# Altium schematic sheet 1166V30-2.SchDoc
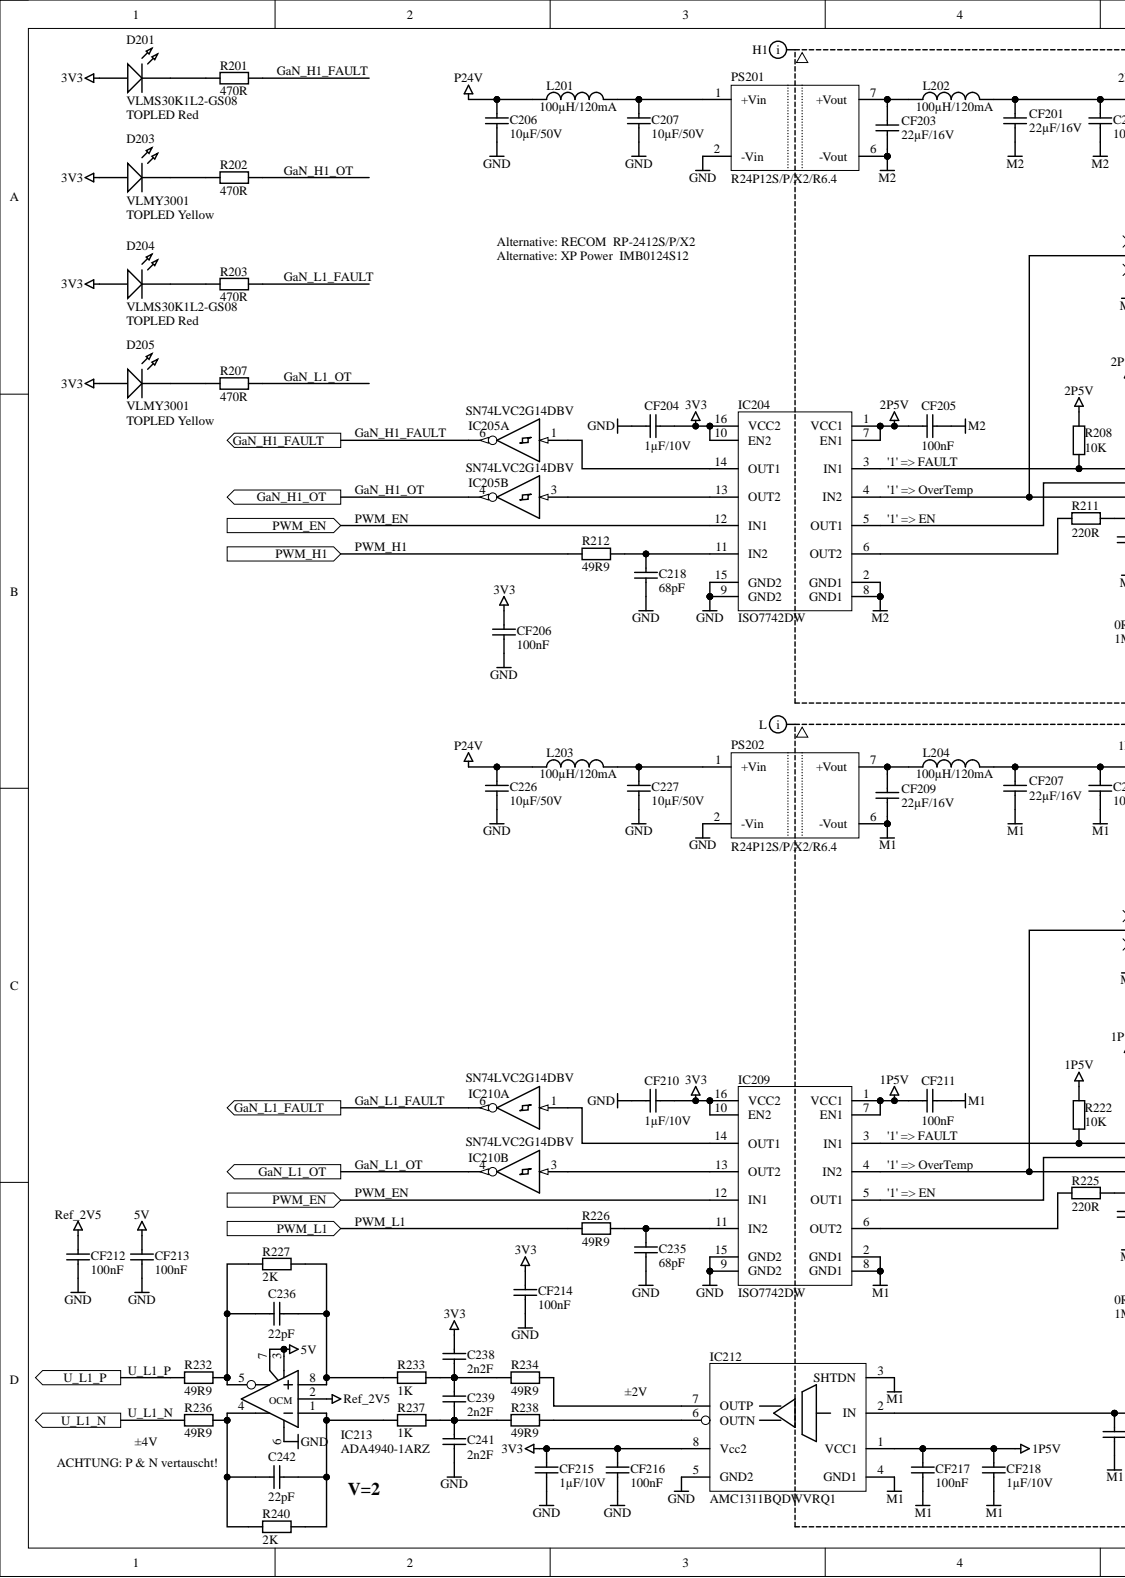
[diagram p0: 1166V30-2.SchDoc, left]
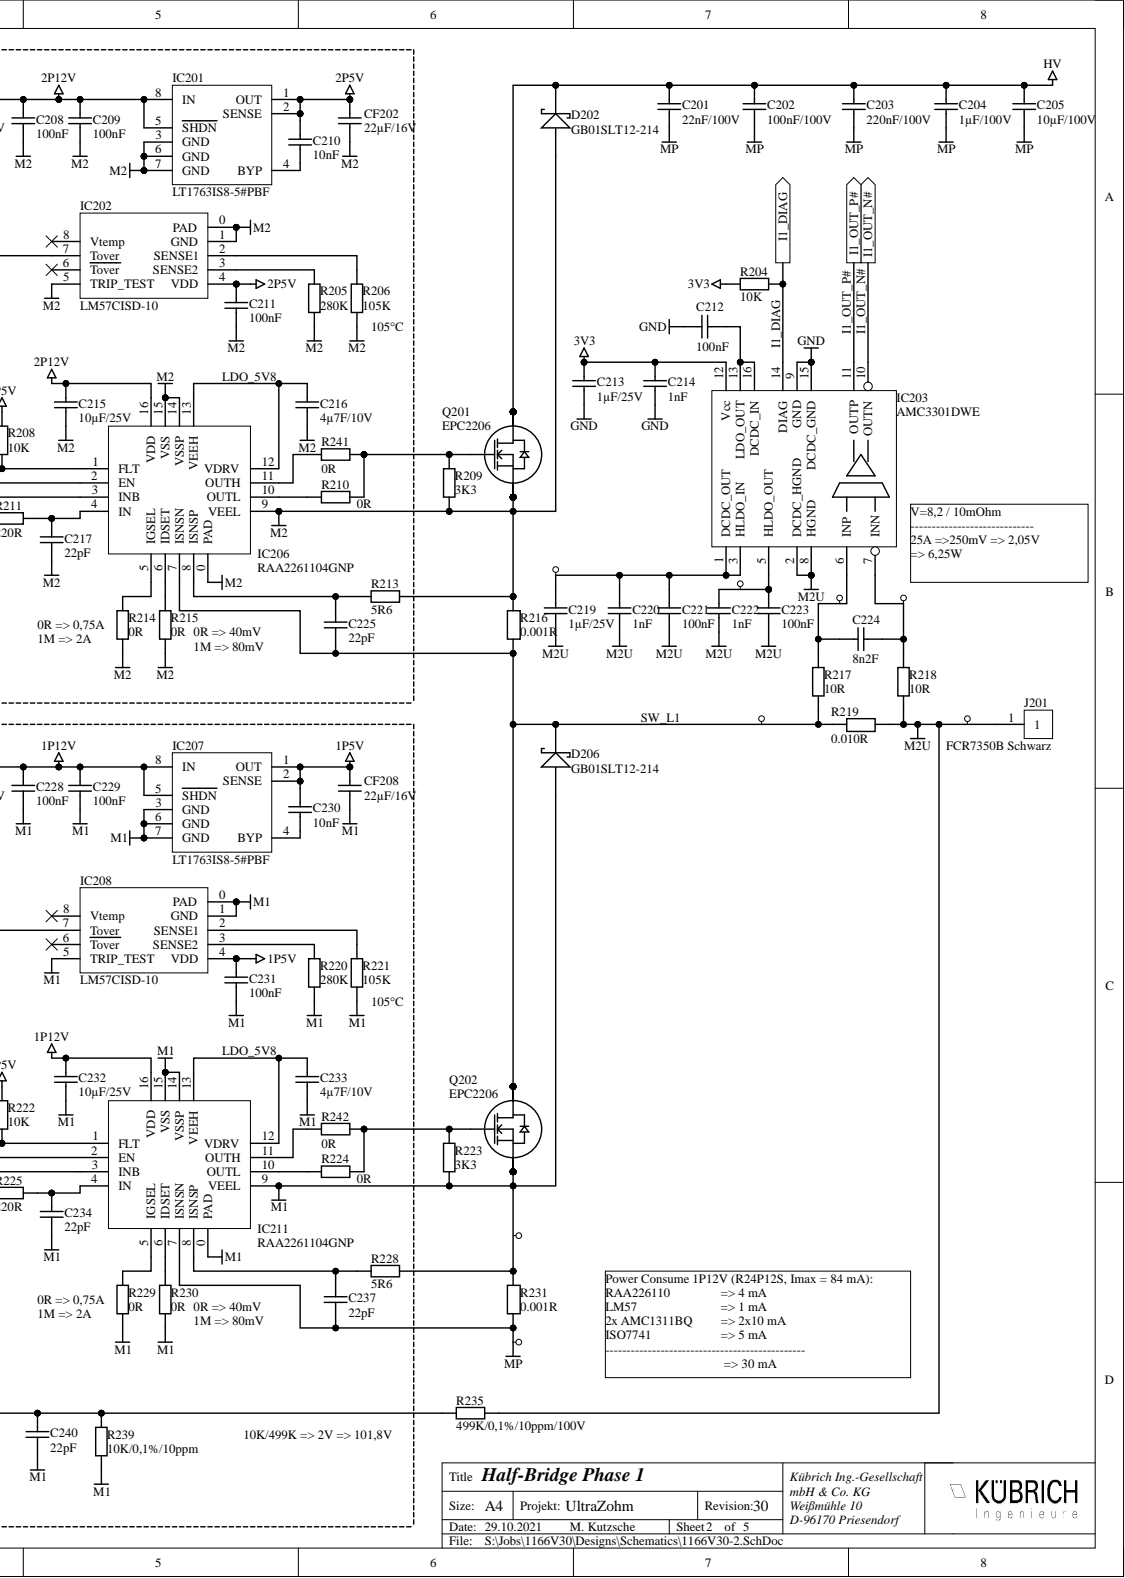
[diagram p1: 1166V30-2.SchDoc, right]

## Parts
C201  22nF/100V  [0805-Cap]
C202  100nF/100V  [0805-Cap]
C203  220nF/100V  [0805-Cap]
C204  1µF/100V  [0805-Cap]
C205  10µF/100V  [1210-Cap]
C206  10µF/50V  [1206-Cap]
C207  10µF/50V  [1206-Cap]
C208  100nF  [0603-Cap]
C209  100nF  [0603-Cap]
C210  10nF  [0603-Cap]
C211  100nF  [0603-Cap]
C212  100nF  [0603-Cap]
C213  1µF/25V  [1206-Cap]
C214  1nF  [1206-Cap]
C215  10µF/25V  [0805-Cap]
C216  4µ7F/10V  [0805-Cap]
C217  22pF  [0603-Cap]
C218  68pF  [0603-Cap]
C219  1µF/25V  [0603-Cap]
C220  1nF  [0603-Cap]
C221  100nF  [0603-Cap]
C222  1nF  [1206-Cap]
C223  100nF  [1206-Cap]
C224  8n2F  [0603-Cap]
C225  22pF  [0603-Cap]
C226  10µF/50V  [1206-Cap]
C227  10µF/50V  [1206-Cap]
C228  100nF  [0603-Cap]
C229  100nF  [0603-Cap]
C230  10nF  [0603-Cap]
C231  100nF  [0603-Cap]
C232  10µF/25V  [0805-Cap]
C233  4µ7F/10V  [0805-Cap]
C234  22pF  [0603-Cap]
C235  68pF  [0603-Cap]
C236  22pF  [0603-Cap]
C237  22pF  [0603-Cap]
C238  2n2F  [0603-Cap]
C239  2n2F  [0603-Cap]
C240  22pF  [0603-Cap]
C241  2n2F  [0603-Cap]
C242  22pF  [0603-Cap]
CF201  22µF/16V  [0805-Cap]
CF202  22µF/16V  [0805-Cap]
CF203  22µF/16V  [0805-Cap]
CF204  1µF/10V  [0603-Cap]
CF205  100nF  [0603-Cap]
CF206  100nF  [0603-Cap]
CF207  22µF/16V  [0805-Cap]
CF208  22µF/16V  [0805-Cap]
CF209  22µF/16V  [0805-Cap]
CF210  1µF/10V  [0603-Cap]
CF211  100nF  [0603-Cap]
CF212  100nF  [0603-Cap]
CF213  100nF  [0603-Cap]
CF214  100nF  [0603-Cap]
CF215  1µF/10V  [0603-Cap]
CF216  100nF  [0603-Cap]
CF217  100nF  [0603-Cap]
CF218  1µF/10V  [0603-Cap]
D201  VLMS30K1L2-GS08  [PLCC-2_rot]
D202  GB01SLT12-214  [DO-214 (SMB)]
D203  VLMY3001  Class II: TOPLED Yellow  [PLCC-2_gelb]
D204  VLMS30K1L2-GS08  Class II: TOPLED Red  [PLCC-2_rot]
D205  VLMY3001  Class II: TOPLED Yellow  [PLCC-2_gelb]
D206  GB01SLT12-214  [DO-214 (SMB)]
IC201  LT1763IS8-5#PBF  [SOIC-8-N]
IC202  LM57CISD-10  [WSON-8 (2.5x2.5mm)]
IC203  AMC3301DWE  [SOIC-16-W - 8.1mm]
IC204  ISO7742DW  [SOIC-16-W - 8.1mm]
IC205  SN74LVC2G14DBV  [SOT-23-6]  (parts A,B)
IC206  RAA2261104GNP  [QFN-16 (4x4mm)]
IC207  LT1763IS8-5#PBF  [SOIC-8-N]
IC208  LM57CISD-10  [WSON-8 (2.5x2.5mm)]
IC209  ISO7742DW  [SOIC-16-W - 8.1mm]
IC210  SN74LVC2G14DBV  [SOT-23-6]  (parts A,B)
IC211  RAA2261104GNP  [QFN-16 (4x4mm)]
IC212  AMC1311BQDWVRQ1  [SOIC-8-W]
IC213  ADA4940-1ARZ  [SOIC-8-N]
J201  FCR7350B Schwarz  [SLB4-I/90 - 4mm - Schwarz - CLIFF]
L201  100µH/120mA  [1007-IND (2518)]
L202  100µH/120mA  [1007-IND (2518)]
L203  100µH/120mA  [1007-IND (2518)]
L204  100µH/120mA  [1007-IND (2518)]
PS201  R24P12S/P/X2/R6.4  [SIP-7 Single - X2]
PS202  R24P12S/P/X2/R6.4  [SIP-7 Single - X2]
Q201  EPC2206  [D0232]
Q202  EPC2206  [D0232]
R201  470R  [0603-Res]
R202  470R  [0603-Res]
R203  470R  [0603-Res]
R204  10K  [0603-Res]
R205  280K  [0603-Res]
R206  105K  [0603-Res]
R207  470R  [0603-Res]
R208  10K  [0603-Res]
R209  3K3  [0603-Res]
R210  0R  [0603-Res]
R211  220R  [0603-Res]
R212  49R9  [0603-Res]
R213  5R6  [0603-Res]
R214  0R  [0603-Res]
R215  0R  [0603-Res]
R216  0.001R  [2512]
R217  10R  [0603-Res]
R218  10R  [0603-Res]
R219  0.010R  [GMR320]
R220  280K  [0603-Res]
R221  105K  [0603-Res]
R222  10K  [0603-Res]
R223  3K3  [0603-Res]
R224  0R  [0603-Res]
R225  220R  [0603-Res]
R226  49R9  [0603-Res]
R227  2K  [0603-Res]
R228  5R6  [0603-Res]
R229  0R  [0603-Res]
R230  0R  [0603-Res]
R231  0.001R  [2512]
R232  49R9  [0603-Res]
R233  1K  [0603-Res]
R234  49R9  [0603-Res]
R235  499K/0,1%/10ppm/100V  [0805-Res]
R236  49R9  [0603-Res]
R237  1K  [0603-Res]
R238  49R9  [0603-Res]
R239  10K/0,1%/10ppm  [0603-Res]
R240  2K  [0603-Res]
R241  0R  [0603-Res]
R242  0R  [0603-Res]

## Ports
GaN_H1_FAULT, GaN_H1_OT, GaN_L1_FAULT, GaN_L1_OT, I1_DIAG, I1_OUT_N#, I1_OUT_P#, PWM_EN, PWM_H1, PWM_L1, U_L1_N, U_L1_P

## Nets
1P5V: C230.1 C231.1 CF208.1 CF211.2 CF217.1 CF218.1 IC207.1(OUT) IC207.2(SENSE) IC208.4(VDD) IC209.1(VCC1) IC209.7(EN1) IC212.1(VCC1) R222.1
1P12V: C228.1 C229.1 C232.2 CF207.1 IC207.5(SHDN) IC207.8(IN) IC211.16(VDD) L204.2
2P5V: C210.1 C211.1 CF202.1 CF205.2 IC201.1(OUT) IC201.2(SENSE) IC202.4(VDD) IC204.1(VCC1) IC204.7(EN1) R208.1
2P12V: C208.1 C209.1 C215.2 CF201.1 IC201.5(SHDN) IC201.8(IN) IC206.16(VDD) L202.2
3V3: C213.1 C214.1 C238.2 CF204.1 CF206.1 CF210.1 CF214.1 CF215.1 CF216.1 D201.1 D203.1 D204.1 D205.1 IC203.12(Vcc) IC204.10(EN2) IC204.16(VCC2) IC209.10(EN2) IC209.16(VCC2) IC212.8(Vcc2) R204.2
5V: CF213.1 IC213.3 IC213.7
GND: C206.1 C207.1 C212.1 C213.2 C214.2 C218.1 C226.1 C227.1 C235.1 C241.1 CF204.2 CF206.2 CF210.2 CF212.2 CF213.2 CF214.2 CF215.2 CF216.2 IC203.9(GND) IC203.15(DCDC_GND) IC204.9(GND2) IC204.15(GND2) IC205.2 IC209.9(GND2) IC209.15(GND2) IC210.2 IC212.5(GND2) IC213.6 PS201.2(-Vin) PS202.2(-Vin)
HV: C201.1 C202.1 C203.1 C204.1 C205.1 D202.2 Q201.3 Q201.4 Q201.7 Q201.8 Q201.11 Q201.12 Q201.15 Q201.16 Q201.19 Q201.20 Q201.23 Q201.24 Q201.27 Q201.28
M1: C228.2 C229.2 C231.2 C232.1 C233.2 C234.1 C240.1 CF207.2 CF208.2 CF209.2 CF211.1 CF217.2 CF218.2 D206.1 IC207.3(GND) IC207.6(GND) IC207.7(GND) IC208.0(PAD) IC208.1(GND) IC208.5(TRIP_TEST) IC209.2(GND1) IC209.8(GND1) IC211.0(PAD) IC211.9(VEEL) IC211.14(VSSP) IC211.15(VSS) IC212.3(SHTDN) IC212.4(GND1) PS202.6(-Vout) Q202.2 Q202.5 Q202.6 Q202.9 Q202.10 Q202.13 Q202.14 Q202.17 Q202.18 Q202.21 Q202.22 Q202.25 Q202.26 Q202.29 Q202.30 R220.2 R221.2 R223.1 R228.2 R229.2 R230.2 R231.2 R239.2
M2: C208.2 C209.2 C211.2 C215.1 C216.2 C217.1 CF201.2 CF202.2 CF203.2 CF205.1 D202.1 IC201.3(GND) IC201.6(GND) IC201.7(GND) IC202.0(PAD) IC202.1(GND) IC202.5(TRIP_TEST) IC204.2(GND1) IC204.8(GND1) IC206.0(PAD) IC206.9(VEEL) IC206.14(VSSP) IC206.15(VSS) PS201.6(-Vout) Q201.2 Q201.5 Q201.6 Q201.9 Q201.10 Q201.13 Q201.14 Q201.17 Q201.18 Q201.21 Q201.22 Q201.25 Q201.26 Q201.29 Q201.30 R205.2 R206.2 R209.1 R213.2 R214.2 R215.2 R216.2
M2U: C219.2 C220.2 C221.2 C222.2 C223.2 IC203.2(DCDC_HGND) IC203.8(HGND) J201.1 R218.1 R219.1 R235.2
MP: C201.2 C202.2 C203.2 C204.2 C205.2 C237.1 IC211.7(ISNSN) R231.1
P24V: C206.2 C226.2 L201.1 L203.1
Ref_2V5: CF212.1 IC213.2(OCM)
VCC: IC205.5 IC210.5
GaN_H1_FAULT: IC205.6 R201.2 ; port GaN_H1_FAULT
GaN_H1_OT: IC205.4 R202.2 ; port GaN_H1_OT
GaN_L1_FAULT: IC210.6 R203.2 ; port GaN_L1_FAULT
GaN_L1_OT: IC210.4 R207.2 ; port GaN_L1_OT
I1_DIAG: IC203.14(DIAG) R204.1 ; port I1_DIAG
I1_OUT_N#: IC203.10(OUTN) ; port I1_OUT_N#
I1_OUT_P#: IC203.11(OUTP) ; port I1_OUT_P#
PWM_EN: IC204.12(IN1) IC209.12(IN1) ; port PWM_EN
PWM_H1: R212.2 ; port PWM_H1
PWM_L1: R226.2 ; port PWM_L1
SW_L1: C225.1 D206.2 IC206.7(ISNSN) Q202.3 Q202.4 Q202.7 Q202.8 Q202.11 Q202.12 Q202.15 Q202.16 Q202.19 Q202.20 Q202.23 Q202.24 Q202.27 Q202.28 R216.1 R217.1 R219.2
U_L1_N: R236.2 ; port U_L1_N
U_L1_P: R232.2 ; port U_L1_P
NetC207_2: C207.2 L201.2 PS201.1(+Vin)
NetC210_2: C210.2 IC201.4(BYP)
NetC212_2: C212.2 IC203.13(LDO_OUT) IC203.16(DCDC_IN)
NetC216_1: C216.1 IC206.12(VDRV) IC206.13(VEEH)
NetC217_2: C217.2 IC206.4(IN) R211.1
NetC218_2: C218.2 IC204.11(IN2) R212.1
NetC219_1: C219.1 C220.1 C221.1 IC203.1(DCDC_OUT) IC203.3(HLDO_IN)
NetC222_1: C222.1 C223.1 IC203.5(HLDO_OUT)
NetC224_1: C224.1 IC203.7(INN) R218.2
NetC224_2: C224.2 IC203.6(INP) R217.2
NetC225_2: C225.2 IC206.8(ISNSP) R213.1
NetC227_2: C227.2 L203.2 PS202.1(+Vin)
NetC230_2: C230.2 IC207.4(BYP)
NetC233_1: C233.1 IC211.12(VDRV) IC211.13(VEEH)
NetC234_2: C234.2 IC211.4(IN) R225.1
NetC235_2: C235.2 IC209.11(IN2) R226.1
NetC236_1: C236.1 IC213.8 R227.1 R233.2
NetC236_2: C236.2 IC213.5 R227.2 R232.1
NetC237_2: C237.2 IC211.8(ISNSP) R228.1
NetC238_1: C238.1 C239.2 R233.1 R234.2
NetC239_1: C239.1 C241.2 R237.1 R238.2
NetC240_2: C240.2 IC212.2(IN) R235.1 R239.1
NetC242_1: C242.1 IC213.1 R237.2 R240.1
NetC242_2: C242.2 IC213.4 R236.1 R240.2
NetCF203_1: CF203.1 L202.1 PS201.7(+Vout)
NetCF209_1: CF209.1 L204.1 PS202.7(+Vout)
NetD201_2: D201.2 R201.1
NetD203_2: D203.2 R202.1
NetD204_2: D204.2 R203.1
NetD205_2: D205.2 R207.1
NetIC202_2: IC202.2(SENSE1) R206.1
NetIC202_3: IC202.3(SENSE2) R205.1
NetIC202_7: IC202.7(Tover) IC204.4(IN2) IC206.3(INB)
NetIC204_3: IC204.3(IN1) IC206.1(FLT) R208.2
NetIC204_5: IC204.5(OUT1) IC206.2(EN)
NetIC204_6: IC204.6(OUT2) R211.2
NetIC204_13: IC204.13(OUT2) IC205.3
NetIC204_14: IC204.14(OUT1) IC205.1
NetIC206_5: IC206.5(IGSEL) R214.1
NetIC206_6: IC206.6(IDSET) R215.1
NetIC206_10: IC206.10(OUTL) R210.1
NetIC206_11: IC206.11(OUTH) R241.1
NetIC208_2: IC208.2(SENSE1) R221.1
NetIC208_3: IC208.3(SENSE2) R220.1
NetIC208_7: IC208.7(Tover) IC209.4(IN2) IC211.3(INB)
NetIC209_3: IC209.3(IN1) IC211.1(FLT) R222.2
NetIC209_5: IC209.5(OUT1) IC211.2(EN)
NetIC209_6: IC209.6(OUT2) R225.2
NetIC209_13: IC209.13(OUT2) IC210.3
NetIC209_14: IC209.14(OUT1) IC210.1
NetIC211_5: IC211.5(IGSEL) R229.1
NetIC211_6: IC211.6(IDSET) R230.1
NetIC211_10: IC211.10(OUTL) R224.1
NetIC211_11: IC211.11(OUTH) R242.1
NetIC212_6: IC212.6(OUTN) R238.1
NetIC212_7: IC212.7(OUTP) R234.1
NetQ201_1: Q201.1 R209.2 R210.2 R241.2
NetQ202_1: Q202.1 R223.2 R224.2 R242.2

Not connected: IC202 6(Tover) 8(Vtemp); IC208 6(Tover) 8(Vtemp)

## Library data of the parts
C201-C242 CF201-CF218 = Cap_SMD: Capacitor (SMD); Class I: Pasiv; Class II: Capacitor; Component Kind: Standard; Last edited: 20.11.2006 10:25; Library Name: KIng_RLC.IntLib
  C201: value: 22nF/100V
  C202: value: 100nF/100V
  C203: value: 220nF/100V
  C204: value: 1µF/100V
  C205: value: 10µF/100V
  C206 C207 C226 C227: value: 10µF/50V
  C208 C209 C211 C212 C221 C223 C228 C229 C231 CF205 CF206 CF211-CF214 CF216 CF217: value: 100nF
  C210 C230: value: 10nF
  C213 C219: value: 1µF/25V
  C214 C220 C222: value: 1nF
  C215 C232: value: 10µF/25V
  C216 C233: value: 4µ7F/10V
  C217 C225 C234 C236 C237 C240 C242: value: 22pF
  C218 C235: value: 68pF
  C224: value: 8n2F
  C238 C239 C241: value: 2n2F
  CF201-CF203 CF207-CF209: value: 22µF/16V
  CF204 CF210 CF215 CF218: value: 1µF/10V
D201 = VLMS30K1L2-GS08: Top LED Super red, 2mA, 18mcd, -40-100°C; Manufacturer: Vishay; Best.-Nr.: Farnell 132-8349; Class I: Discrete; Class II: TOPLED Red; Component Kind: Standard; Last edited: 23.01.2007 08:58; Library Name: KIng_Dioden.IntLib
D202 D206 = GB01SLT12-214: Silicon Carbide Schottky Diode Vr=1200V If=1A; Manufacturer: GeneSiC Semiconductor; Best.-Nr.: DigiKey: 1242-1169-1-ND; Class I: Discret; Package information: Unknown; Class II: Silicon Carbide Schottky Diode Vr=1200V If=1A; Component Kind: Standard; Datasheet: Ver. 7 Nov 2000; Package reference: DO-214AA (SMB); Library Name: KIng_Dioden.IntLib
D203 D205 = VLMY3001: Top LED Yellow, 2mA, 18mcd, -40-100°C; Manufacturer: Vishay; Best.-Nr.: ???; Class I: Discrete; Component Kind: Standard; Last edited: 23.01.2007 08:58; Library Name: KIng_Dioden.IntLib
D204 = VLMS30K1L2-GS08: Top LED Super red, 2mA, 18mcd, -40-100°C; Manufacturer: Vishay; Best.-Nr.: Farnell 132-8349; Class I: Discrete; Component Kind: Standard; Last edited: 23.01.2007 08:58; Library Name: KIng_Dioden.IntLib
IC201 IC207 = LT1763IS8-5#PBF: LDO 5V/500mA, Vin 20V (-40-125°C); Manufacturer: Linear Technology; Best.-Nr.: Farnell 229-5214; Class I: Discret; Package information: Unknown; Class II: LDO 5V/500mA, Vin 20V (-40-125°C); Component Kind: Standard; Datasheet: ???; Package reference: SO-8; Library Name: KIng_Voltage Regulator.IntLib
IC202 IC208 = LM57CISD-10: Temperature Sensor/Switch -40 - 150°C, Resistor-Programmable, 10°C Hysterese; Manufacturer: Texas Instruments; Best.-Nr.: DigiKey: LM57CISD-10/NOPBCT-ND; Class I: Sensor; Class II: Temperature Sensor/Switch -40 - 150°C, Resistor-Programmable, 10°C Hysterese; Component Kind: Standard; Library Name: King_Sensor.IntLib
IC203 = AMC3301DWE: ±250 mV Input Voltage Range, Reinforced Isolated Amplifier and High Bandwidth of 300 kHz; Manufacturer: Texas Instruments; Best.-Nr.: DigiKey: 296-AMC3301DWE-ND; Class II: ±250 mV, 300KHz, -40-125°C; Package information: Unknown; Class I: Isolated Amplifier; Component Kind: Standard; Datasheet: Unknown; Package reference: SOIC-16-W; Library Name: KIng_Opto-Coupler.IntLib
IC204 IC209 = ISO7742DW: Quad-CH 100Mbps Isolators 2 IN/2 OUT, 5000V Reinforced, (-40-125°C); Manufacturer: Texas Instruments; Best.-Nr.: DigiKey: 296-44609-1-ND; Class I: Optocoupler; Package information: Unknown; Class II: Quad-CH 100Mbps Isolators 2 IN/2 OUT, 5000V Reinforced, (-40-125°C); Component Kind: Standard; Datasheet: Unknown; Package reference: SOIC-16W; Library Name: KIng_Opto-Coupler.IntLib
IC205 IC210 = SN74LVC2G14D_: Dual Inverter with Schmitt Trigger Input; Manufacturer: Texas Instrument; Class I: Logic; value121: SN74LVC2G14DCK; Class II: Dual Inverter with Schmitt Trigger Input; Component Kind: Standard; Datasheet: SCES200J; Last edited: 22.01.2007 11:08; value111: SN74LVC2G14DBV; Library Name: KIng_Logik.IntLib
IC206 IC211 = RAA2261104GNP: Low -Side GaN FET-Driver, 6,5V - 18V; Manufacturer: Renesas; Best.-Nr.: Mouser: 968-2261104GNP#AA0; Class I: Discret; Package information: Unknown; Class II: Low -Side GaN FET-Driver, 6,5V - 18V; Component Kind: Standard; Datasheet: Rev. Mar 2002; Package reference: QFN-16; Library Name: KIng_MOSFET.IntLib
IC212 = AMC1311BQDWVRQ1: 2V Input, Automotive High-Impedance, Reinforced Isolated Amplifier for Voltage Sensing; Manufacturer: Texas Instruments; Best.-Nr.: Mouser: 595-AMC1311BQDWVRQ1; Class II: 2V, 275KHz, -40-125°C; Package information: Unknown; Class I: Isolated Amplifier; Component Kind: Standard; Datasheet: Unknown; Package reference: SOIC-8-W; Library Name: KIng_Opto-Coupler.IntLib
IC213 = ADA4940-1ARZ: Fully Differential ADC Drivers (-40-125°C); Manufacturer: ANALOG DEVICES; Best.-Nr.: Farnell 209-6178; Class I: Analog; Class II: Fully Differential ADC Drivers (-40-125°C); Component Kind: Standard; Datasheet: DSO11449, Ver. Aug 2000; Last edited: 04.01.2007 10:14; Package Reference: SOIC-N-8; Library Name: KIng_Analog-IC.IntLib
J201 = FCR7350B Schwarz: Labor Winkelbuchsen 4mm Schwarz; Manufacturer: CLIFF Electronic; Best.-Nr.: Farnell: 185-4507; Class I: Connectors; Class II: Labor Winkelbuchsen 4mm Schwarz; Component Kind: Standard; Last edited: 07.03.2007 13:28; Library Name: KIng_Connector.IntLib
L201-L204 = CB2518T101K: 100µH, 60/120mA. (1007), -40-105°C; Manufacturer: Taiyo Yuden; Best.-Nr.: DigiKey:   587-2192-1-ND; Class I: Pasive; Class II: 100µH, 60/120mA. (1007), -40-105°C; Component Kind: Standard; Last edited: 08.12.2006 12:28; Library Name: KIng_RLC.IntLib
PS201 PS202 = R24P12S/P/X2/R6.4: DC/DC Converter 24V to 12V, 1W, 84mA (unregulated) 6,4KVDC Rainforced; Manufacturer: Recom; Best.-Nr.: Mouser: 919-R24P12SX2PR6.4; Class I: Analog; Package information: Unknown; Class II: DC/DC Converter 24V to 12V, 1W, 84mA (unregulated) 6,4KVDC Rainforced; Component Kind: Standard; Datasheet: Rev. 28 Apr 2002; Library Name: KIng_DCDC-Converter.IntLib; Package reference: SIP-7
Q201 Q202 = EPC2206: GaN-Fet 80V, 2.2mOhm, 90A; Manufacturer: EPC; Best.-Nr.: DigiKey: 917-1212-1-ND; Class I: Discret; Package information: Unknown; Class II: GaN-Fet 80V, 2.2mOhm, 90A; Component Kind: Standard; Datasheet: Rev. Mar 2002; Package reference: DIE; Library Name: KIng_MOSFET.IntLib
R201-R215 R217 R218 R220-R230 R232-R242 = Res_SMD: Resistor SMD; Class I: Pasiv; Class II: Resistor; Component Kind: Standard; Last edited: 17.11.2006 11:38; Library Name: KIng_RLC.IntLib
  R201-R203 R207: value: 470R
  R204 R208 R222: value: 10K
  R205 R220: value: 280K
  R206 R221: value: 105K
  R209 R223: value: 3K3
  R210 R214 R215 R224 R229 R230 R241 R242: value: 0R
  R211 R225: value: 220R
  R212 R226 R232 R234 R236 R238: value: 49R9
  R213 R228: value: 5R6
  R217 R218: value: 10R
  R227 R240: value: 2K
  R233 R237: value: 1K
  R235: value: 499K/0,1%/10ppm/100V
  R239: value: 10K/0,1%/10ppm
R216 R231 = CSS2H-2512R-1L00F: Shunt 0.001R, 5W, 1%, 50ppm/°C; Manufacturer: Bourns; Best.-Nr.: Farnell 257-6347; Class I: Pasiv; Class II: Shunt 5W; Component Kind: Standard; Last edited: 23.11.2010 14:12; value: 0.001R; Library Name: KIng_Shunt.IntLib
R219 = GMR320HJBFA10L0: Shunt 0.010R, 10W, 1%, 25ppm; Manufacturer: ROHM; Best.-Nr.: DigiKey 511-GMR320HJBFA10L0CT-ND; Mouser: 755-GMR320HJBFA10L0; Class I: Pasiv; Class II: Shunt 10W; Component Kind: Standard; Last edited: 23.11.2006 14:12; value: 0.010R; Library Name: KIng_Shunt.IntLib
